# Altium schematic sheet Sway Cry Rev D - Sheet 2.SchDoc
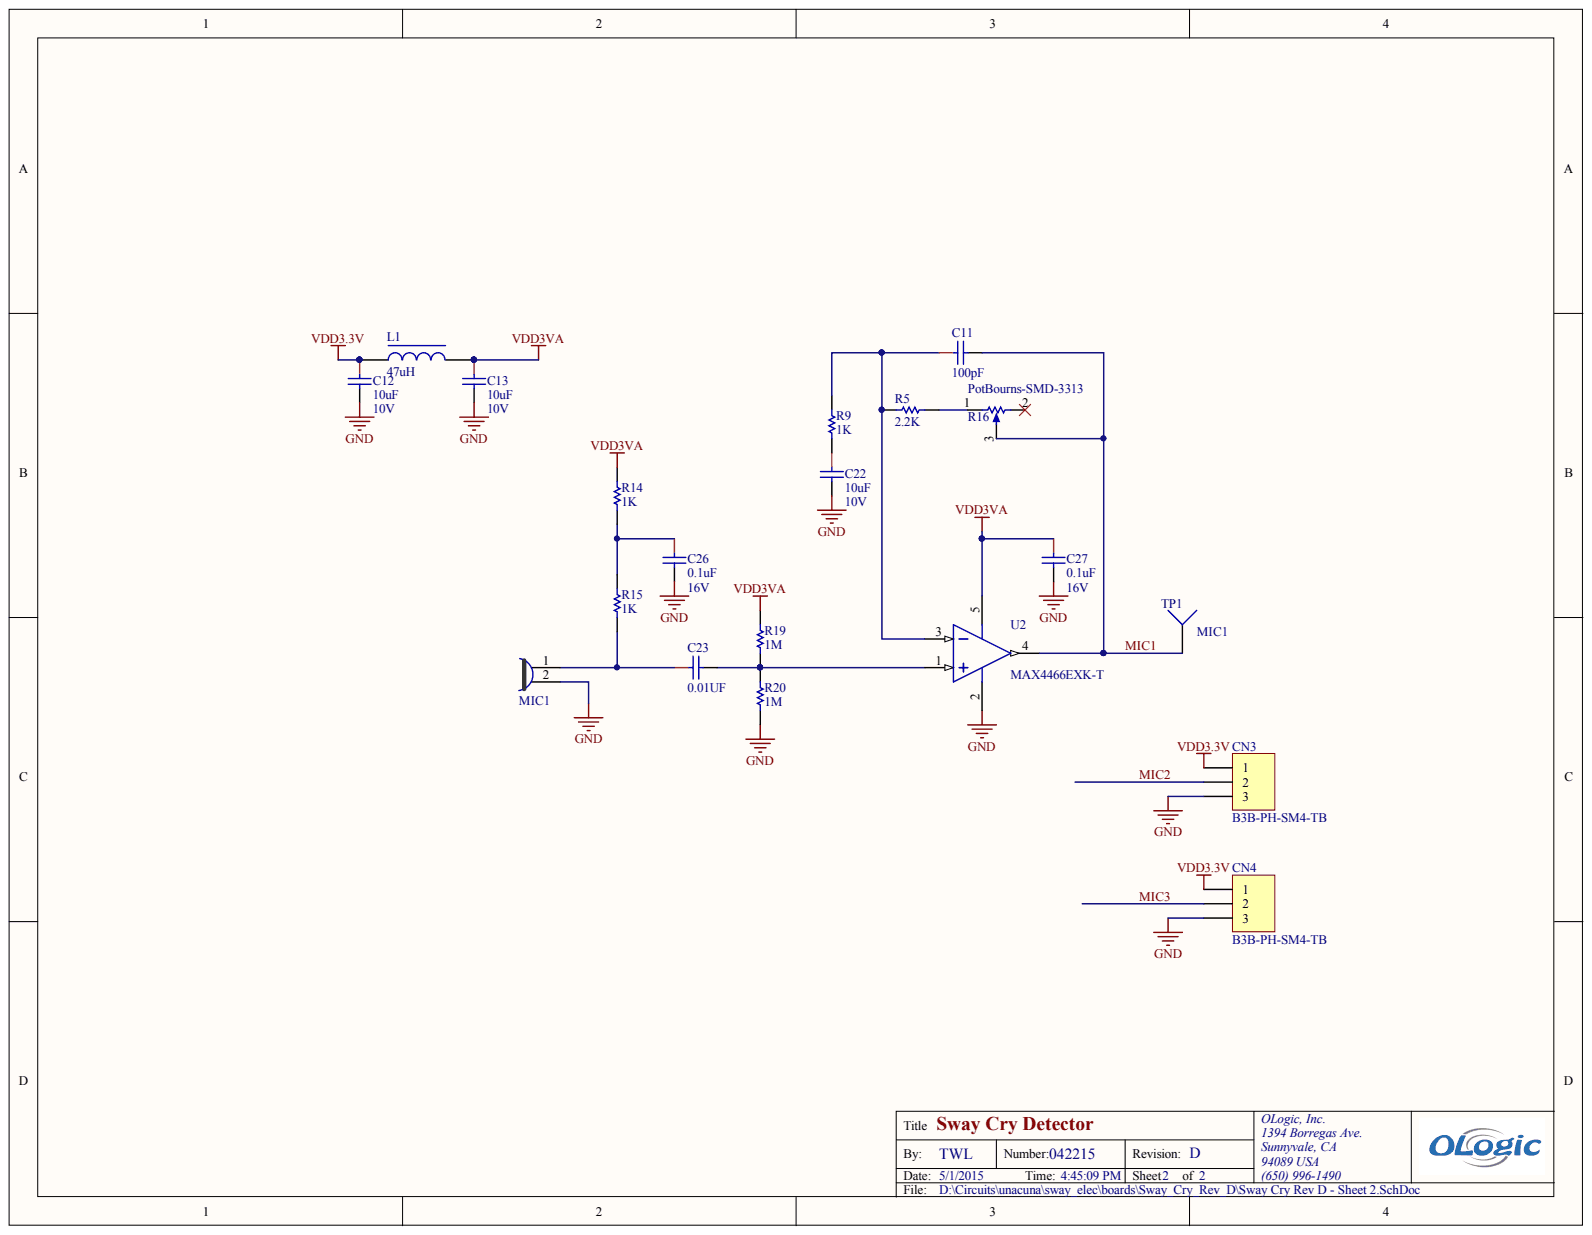
[diagram p0: Sway Cry Rev D - Sheet 2.SchDoc]

## Parts
C11  100pF  [CAPC0603(1608)_N]
C12  10uF  Voltage - Rated: 10V  [CAPC0603(1608)_N]
C13  10uF  Voltage - Rated: 10V  [CAPC0603(1608)_N]
C22  10uF  Voltage - Rated: 10V  [CAPC0603(1608)_N]
C23  0.01UF  [CAPC0603(1608)_N]
C26  0.1uF  Voltage - Rated: 16V  [CAPC0603(1608)_N]
C27  0.1uF  Voltage - Rated: 16V  [CAPC0603(1608)_N]
CN3  B3B-PH-SM4-TB  [JST-B3B-PH-SM4-TB]
CN4  B3B-PH-SM4-TB  [JST-B3B-PH-SM4-TB]
L1  47uH  [IND-2012 Chip]
MIC1  CMA-4544PF-W  [MICROPHONE-9.7mm]  (value not drawn)
R5  2.2K  [RESC0603(1608)_N]
R9  1K  [RESC0603(1608)_N]
R14  1K  [RESC0603(1608)_N]
R15  1K  [RESC0603(1608)_N]
R16  PotBourns-SMD-3313  [POT-3313]
R19  1M  [RESC0603(1608)_N]
R20  1M  [RESC0603(1608)_N]
TP1  MIC1  [TestPoint-TH]
U2  MAX4466EXK-T  [SOT353]

## Nets
GND: C12.2 C13.2 C22.2 C26.2 C27.2 CN3.3 CN4.3 MIC1.2 R20.1 U2.2
VDD3.3V: C12.1 CN3.1 CN4.1 L1.1
VDD3VA: C13.1 C27.1 L1.2 R14.2 R19.2 U2.5
MIC1: C11.2 R16.3 TP1.1 U2.4
MIC2: CN3.2
MIC3: CN4.2
NetC11_1: C11.1 R5.2 R9.2 U2.3
NetC22_1: C22.1 R9.1
NetC23_1: C23.1 MIC1.1 R15.1
NetC23_2: C23.2 R19.1 R20.2 U2.1
NetC26_1: C26.1 R14.1 R15.2
NetR5_1: R5.1 R16.1

Not connected: R16 2

## Library data of the parts
C11 = CAP CER 100PF 50V 5% NP0 0603: 100pF 0603 5%; Manufacturer: Kemet; Manufacturer Part Number: C0603C101J5GACTU; Temperature Coefficient: C0G, NP0; Voltage - Rated: 50V; Applications: General Purpose; Capacitance: 100pF; Category: Capacitors; Component Kind: Standard; ComponentLink1Description: http://www.kemet.com/datasheets&C0603C101J5GACTU; ComponentLink1URL: http://www.kemet.com/datasheets&C0603C101J5GACTU; Features: -; Height - Seated (Max): -; Lead Spacing: -; Lead Style: -; Library Name: OLogic-Passive.IntLib; Mounting Type: Surface Mount, MLCC; Operating Temperature: -55°C ~ 125°C; Package / Case: 0603 (1608 Metric); Packaging: Cut Tape (CT); Pricing 1: 1=0.1, 10=0.029, 25=0.0208, 50=0.016, 100=0.0135, 250=0.011, 1000=0.0072 (USD); Ratings: -; RoHS: RoHS Compliant; Size / Dimension: 0.063" L x 0.031" W (1.60mm x 0.80mm); Stock 1: 476541; Supplier 1: Digi-Key; Supplier Part Number 1: 399-1061-1-ND; Thickness (Max): 0.034" (0.87mm); Tolerance: ±5%
C12 C13 C22 = CAP CER 10UF 10V Y5V 0805: 10uF 0805 10V; Manufacturer: Taiyo Yuden; Manufacturer Part Number: LMK212F106ZG-T; Capacitance: 10µF; Temperature Coefficient: Y5V (F); Tolerance: -20%, +80%; Applications: General Purpose; Category: Capacitors; Component Kind: Standard; ComponentLink1Description: http://www.yuden.co.jp/or/product/category/capacitor/LMK212F106ZG-T.pdf; ComponentLink1URL: http://www.yuden.co.jp/or/product/category/capacitor/LMK212F106ZG-T.pdf; Features: -; Height - Seated (Max): -; Lead Spacing: -; Lead Style: -; Library Name: OLogic-Passive.IntLib; Mounting Type: Surface Mount, MLCC; Operating Temperature: -30°C ~ 85°C; Package / Case: 0805 (2012 Metric); Packaging: Cut Tape (CT); Pricing 1: 1=0.17, 10=0.115, 50=0.0662, 100=0.0546, 250=0.046, 500=0.03852, 1000=0.03163 (USD); Ratings: -; RoHS: RoHS Compliant; Size / Dimension: 0.079" L x 0.049" W (2.00mm x 1.25mm); Stock 1: 194456; Supplier 1: Digi-Key; Supplier Part Number 1: 587-1312-1-ND; Thickness (Max): 0.053" (1.35mm)
C23 = CAP CER 0.01UF 16V 10% X7R 0603: 0.01UF 16V; Manufacturer: Kemet; Manufacturer Part Number: C0603C103K4RACTU; Capacitance: 10000pF; Temperature Coefficient: X7R; Tolerance: ±10%; Applications: General Purpose; Category: Capacitors; Component Kind: Standard; ComponentLink1Description: http://www.kemet.com/datasheets&C0603C103K4RACTU; ComponentLink1URL: http://www.kemet.com/datasheets&C0603C103K4RACTU; Features: -; Height - Seated (Max): -; Lead Spacing: -; Lead Style: -; Library Name: OLogic-Passive.IntLib; Mounting Type: Surface Mount, MLCC; Operating Temperature: -55°C ~ 125°C; Package / Case: 0603 (1608 Metric); Packaging: Tape & Reel (TR); Pricing 1: 4000=0.042, 8000=0.0399, 12000=0.0378, 28000=0.0357, 100000=0.02835 (USD); Ratings: -; RoHS: RoHS Compliant; Size / Dimension: 0.063" L x 0.031" W (1.60mm x 0.80mm); Stock 1: 40000; Supplier 1: Digi-Key; Supplier Part Number 1: 399-7841-2-ND; Thickness (Max): 0.034" (0.87mm); Voltage - Rated: 16V
C26 C27 = CAP CER 0.1UF 16V 10% X7R 0603: 0.1uF 0603 16V; Manufacturer: Kemet; Manufacturer Part Number: C0603C104K4RACTU; Capacitance: 0.1µF; Temperature Coefficient: X7R; Tolerance: ±10%; Applications: General Purpose; Category: Capacitors; Component Kind: Standard; ComponentLink1Description: http://www.kemet.com/datasheets&C0603C104K4RACTU; ComponentLink1URL: http://www.kemet.com/datasheets&C0603C104K4RACTU; Features: -; Height - Seated (Max): -; Lead Spacing: -; Lead Style: -; Library Name: OLogic-Passive.IntLib; Mounting Type: Surface Mount, MLCC; Operating Temperature: -55°C ~ 125°C; Package / Case: 0603 (1608 Metric); Packaging: Tape & Reel (TR); Pricing 1: 4000=0.00414, 8000=0.00378, 12000=0.0036, 28000=0.00342, 100000=0.00248 (USD); Ratings: -; RoHS: RoHS Compliant; Size / Dimension: 0.063" L x 0.031" W (1.60mm x 0.80mm); Stock 1: 2360000; Supplier 1: Digi-Key; Supplier Part Number 1: 399-1096-2-ND; Thickness (Max): 0.034" (0.87mm)
CN3 CN4 = JST-B3B-PH-SM4-TB: CONN HEADER PH TOP 3POS 2MM SMD; Manufacturer: JST Sales America Inc; Manufacturer Part Number: B3B-PH-SM4-TB(LF)(SN)(P); Connector Type: Header, Shrouded; Number of Positions: 3; Number of Positions Loaded: All; Pitch: 0.079" (2.00mm); Category: Connectors, Interconnects; Color: Natural; Component Kind: Standard; ComponentLink1Description: http://www.jst-mfg.com/product/pdf/eng/ePH.pdf; ComponentLink1URL: http://www.jst-mfg.com/product/pdf/eng/ePH.pdf; Contact Finish: Tin; Contact Finish Thickness: -; Contact Mating Length: 0.130" (3.30mm); Contact Type: Male Pin; Fastening Type: Detent Lock; Features: Solder Retention; Library Name: OLogic-Connectors.IntLib; Mounting Type: Surface Mount; Number of Rows: 1; Packaging: Cut Tape (CT); Pricing 1: 1=0.6, 10=0.566, 25=0.5096, 50=0.4528, 100=0.434, 250=0.39628, 500=0.37742 (USD); RoHS: RoHS Compliant; Row Spacing: -; Stock 1: 32550; Supplier 1: Digi-Key; Supplier Part Number 1: 455-1735-1-ND; Termination: Solder
L1 = INDUCTOR MULTILAYER 47UH 100mA 0805: 47uH 0805; Manufacturer: TDK Corporation; Manufacturer Part Number: MLZ2012M470WT000; Current - Saturation: 50mA; Current Rating: 170mA; DC Resistance (DCR): 3.7 Ohm; Frequency - Self Resonant: 20MHz; Frequency - Test: 2MHz; Inductance: 47µH; Material - Core: Ferrite; Shielding: Shielded; Tolerance: ±20%; Category: Inductors, Coils, Chokes; Component Kind: Standard; ComponentLink1Description: http://www.tdk.co.jp/tefe02/e533_mlz.pdf; ComponentLink1URL: http://www.tdk.co.jp/tefe02/e533_mlz.pdf; Height - Seated (Max): 0.057" (1.45mm); Library Name: OLogic-Passive.IntLib; Mounting Type: Surface Mount; Operating Temperature: -55°C ~ 125°C; Package / Case: 0805 (2012 Metric); Packaging: Cut Tape (CT); Pricing 1: 1=0.14, 10=0.125, 50=0.0976, 100=0.0875, 500=0.075, 1000=0.065 (USD); Q @ Freq: -; RoHS: RoHS Compliant; Size / Dimension: 0.079" L x 0.049" W (2.00mm x 1.25mm); Stock 1: 47288; Supplier 1: Digi-Key; Supplier Device Package: 0805 (2012 Metric); Supplier Part Number 1: 445-6400-1-ND; Type: Multilayer
MIC1 = CMA-4544PF-W: Electret Condenser Microphone 9.7mm; Manufacturer: CUI Inc; Current - Supply: 500µA; Direction: Omnidirectional; Frequency Range: 20Hz ~ 20kHz; Impedance: 2.2 kOhm; Output Type: Analog; Port Location: Top; S/N Ratio: 60dB; Seal Rating: None; Sensitivity: -44dB ±2dB @ 94dB SPL; Shape: Circular; Voltage - Rated: 3 ~ 10V; Category: Audio Products; Component Kind: Standard; ComponentLink1Description: http://www.cui.com/product/resource/digikeypdf/cma-4544pf-w.pdf; ComponentLink1URL: http://www.cui.com/product/resource/digikeypdf/cma-4544pf-w.pdf; Height (Max): 4.70mm; Library Name: OLogic-Misc.IntLib; Manufacturer Part Number: CMA-4544PF-W; Packaging: Bulk; Pricing 1: 1=0.96, 10=0.746, 100=0.5693, 500=0.4554, 1000=0.4048, 2500=0.33649 (USD); RoHS: RoHS Compliant; Size / Dimension: 9.70mm Dia; Stock 1: 40102; Supplier 1: Digi-Key; Supplier Part Number 1: 102-1721-ND; Termination: PC Pins; Type: Electret Condenser
R5 = RES 2.2K OHM 5% 1/10W 0603: 2.2K 0603; Manufacturer: Panasonic Electronic Components; Manufacturer Part Number: ERJ-3GEYJ222V; Power (Watts): 0.1W, 1/10W; Temperature Coefficient: ±200ppm/°C; Tolerance: ±5%; Category: Resistors; Component Kind: Standard; ComponentLink1Description: http://industrial.panasonic.com/www-cgi/jvcr13pz.cgi?E+PZ+3+AOA0001+ERJ3GEYJ222V+7+WW; ComponentLink1URL: http://industrial.panasonic.com/www-cgi/jvcr13pz.cgi?E+PZ+3+AOA0001+ERJ3GEYJ222V+7+WW; Composition: Thick Film; Features: Automotive AEC-Q200; Height: 0.022" (0.55mm); Library Name: OLogic-Passive.IntLib; Number of Terminations: 2; Operating Temperature: -55°C ~ 155°C; Package / Case: 0603 (1608 Metric); Packaging: Cut Tape (CT); Pricing 1: 1=0.1, 50=0.0126, 100=0.0096, 250=0.00716, 500=0.00528, 1000=0.00352, 2500=0.00297 (USD); Resistance (Ohms): 2.2k; RoHS: RoHS Compliant; Signal Integrity: Res; Simulation: RESISTOR; Size / Dimension: 0.063" L x 0.031" W (1.60mm x 0.80mm); Stock 1: 327206; Supplier 1: Digi-Key; Supplier Device Package: 0603; Supplier Part Number 1: P2.2KGCT-ND
R9 R14 R15 = RES 1K OHM 1/10W 5% 0603 SMD: 1K 0603; Manufacturer: Panasonic Electronic Components; Manufacturer Part Number: ERJ-3GEYJ102V; Power (Watts): 0.1W, 1/10W; Temperature Coefficient: ±200ppm/°C; Tolerance: ±5%; Category: Resistors; Component Kind: Standard; ComponentLink1Description: http://industrial.panasonic.com/www-cgi/jvcr13pz.cgi?E+PZ+3+AOA0001+ERJ3GEYJ102V+7+WW; ComponentLink1URL: http://industrial.panasonic.com/www-cgi/jvcr13pz.cgi?E+PZ+3+AOA0001+ERJ3GEYJ102V+7+WW; Composition: Thick Film; Features: -; Height: 0.022" (0.55mm); Library Name: OLogic-Passive.IntLib; Number of Terminations: 2; Package / Case: 0603 (1608 Metric); Packaging: Cut Tape (CT); Pricing 1: 1=0.1, 50=0.0126, 100=0.0096, 250=0.00716, 500=0.00528, 1000=0.00352, 2500=0.00297 (USD); Resistance (Ohms): 1k; RoHS: RoHS Compliant; Simulation: RESISTOR; Size / Dimension: 0.063" L x 0.031" W (1.60mm x 0.80mm); Stock 1: 4525527; Supplier 1: Digi-Key; Supplier Device Package: 0603; Supplier Part Number 1: P1.0KGCT-ND
R16 = PotBourns-SMD-3313: Top Adjust Potentiometer; Manufacturer: Bourns; MPN: 3313J-1-203E; PN: 3313J-203ECT-ND; Vendor: Digikey; Component Kind: Standard; Library Name: OLogic-Passive.IntLib
R19 R20 = RES 1M OHM 1/10W 1% 0603 SMD: 1M 0603; Manufacturer: Panasonic Electronic Components; Manufacturer Part Number: ERJ-3EKF1004V; Power (Watts): 0.1W, 1/10W; Temperature Coefficient: ±100ppm/°C; Tolerance: ±1%; Category: Resistors; Component Kind: Standard; ComponentLink1Description: http://industrial.panasonic.com/www-cgi/jvcr13pz.cgi?E+PZ+3+AOA0002+ERJ3EKF1004V+7+WW; ComponentLink1URL: http://industrial.panasonic.com/www-cgi/jvcr13pz.cgi?E+PZ+3+AOA0002+ERJ3EKF1004V+7+WW; Composition: Thick Film; Features: -; Height: 0.022" (0.55mm); Library Name: OLogic-Passive.IntLib; Number of Terminations: 2; Package / Case: 0603 (1608 Metric); Packaging: Cut Tape (CT); Pricing 1: 1=0.1, 50=0.015, 100=0.0114, 250=0.00844, 500=0.00624, 1000=0.00416, 2500=0.00351 (USD); Resistance (Ohms): 1M; RoHS: RoHS Compliant; Signal Integrity: Res; Simulation: RESISTOR; Size / Dimension: 0.063" L x 0.031" W (1.60mm x 0.80mm); Stock 1: 709119; Supplier 1: Digi-Key; Supplier Device Package: 0603; Supplier Part Number 1: P1.00MHCT-ND
TP1 = TestPoint: Test Point; Component Kind: Standard; Library Name: OLogic-Misc.IntLib
U2 = MAX4466EXK-T: IC PREAMP MICROPHONE SOT353; Manufacturer: Maxim Integrated; Output Type: 1-Channel (Mono); Voltage - Supply: 2.4 V ~ 5.5 V; Category: Integrated Circuits (ICs); Component Kind: Standard; ComponentLink1Description: http://datasheets.maximintegrated.com/en/ds/MAX4465-MAX4469.pdf; ComponentLink1URL: http://datasheets.maximintegrated.com/en/ds/MAX4465-MAX4469.pdf; Features: Microphone; Library Name: OLogic-OpAmps.IntLib; Manufacturer Part Number: MAX4466EXK-T; Max Output Power x Channels @ Load: -; Mounting Type: Surface Mount; Operating Temperature: -40°C ~ 85°C (TA); Package / Case: 5-TSSOP, SC-70-5, SOT-353; Packaging: Tape & Reel (TR); Pricing 1: 0=0 (USD); RoHS: RoHS non-compliant; Stock 1: 0; Supplier 1: Digi-Key; Supplier Device Package: SC-70-5; Supplier Part Number 1: MAX4466EXK-T-ND; Type: Class AB
